# Altium schematic sheet Sch-QWA17_Power.SchDoc(Sch-QWA17_Power)
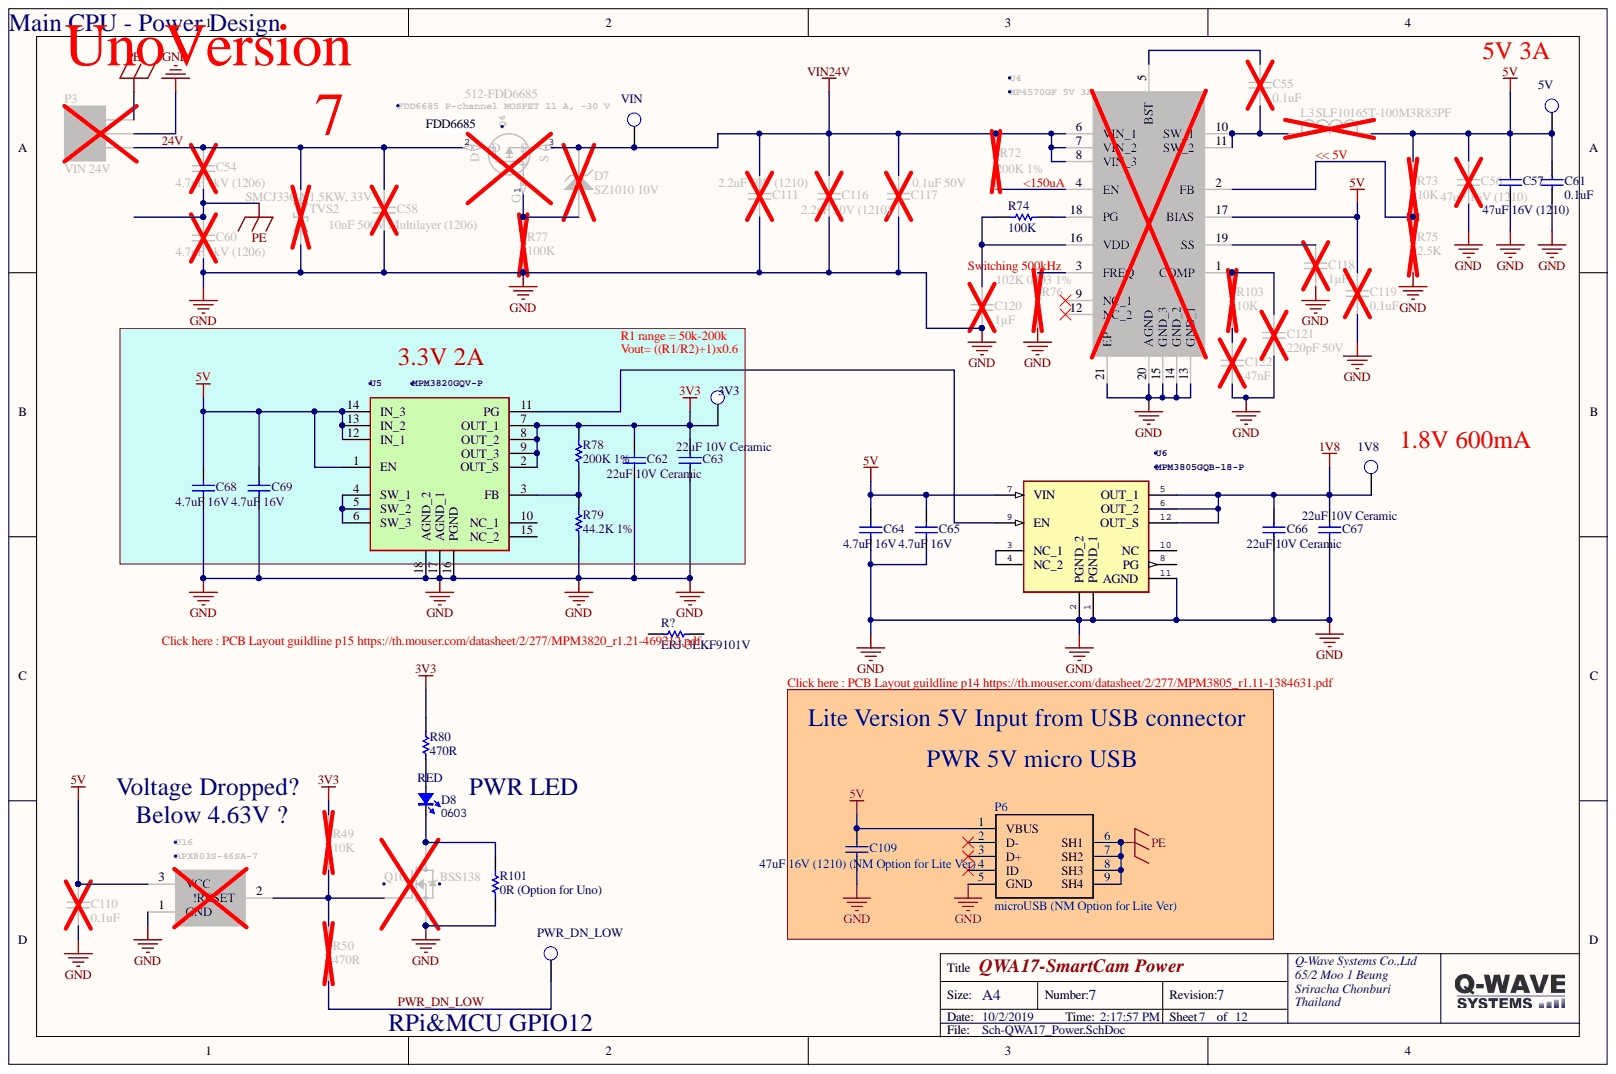
[diagram p0: Sch-QWA17_Power.SchDoc]

## Parts
1V8  1V8  [TEST_POINT]
3V3  3V3  [TEST_POINT]
5V  5V  [TEST_POINT]
C54  4.7nF 1kV (1206)  [C 1206]
C55  0.1uF  [CAP_0603]
C56  47uF 16V (1210)  [C 1210]
C57  47uF 16V (1210)  [C 1210]
C58  10nF 500V Multilayer (1206)  [C 1206]
C60  4.7nF 1kV (1206)  [C 1206]
C61  0.1uF  [CAP_0603]
C62  22uF 10V Ceramic  [CAP_0805]
C63  22uF 10V Ceramic  [CAP_0805]
C64  4.7uF 16V  [CAP_0805]
C65  4.7uF 16V  [CAP_0805]
C66  22uF 10V Ceramic  [CAP_0805]
C67  22uF 10V Ceramic  [CAP_0805]
C68  4.7uF 16V  [CAP_0805]
C69  4.7uF 16V  [CAP_0805]
C109  47uF 16V (1210) (NM Option for Lite Ver)  [C 1210]
C110  0.1uF  [CAP_0603]
C111  2.2uF 50V (1210)  [C 1210]
C116  2.2uF 50V (1210)  [C 1210]
C117  0.1uF 50V  [CAP_0603]
C118  1µF  [CAP_0603]
C119  0.1uF  [CAP_0603]
C120  1µF  [CAP_0603]
C121  220pF 50V  [CAP_0603]
C122  47nF  [CAP_0603]
D7  SZ1010 10V  [DO-214AC_SMA]
D8  RED  Type: 0603  [LED_0603_RED]
L3  SLF10165T-100M3R83PF  [SLF10165T-100M3R83PF]
P3  VIN 24V  [FCI 06-381-3PIN]
P6  microUSB (NM Option for Lite Ver)  [MICRO-USB-CON]
PWR_DN_LOW  PWR_DN_LOW  [TEST_POINT]
Q4  FDD6685 P-channel MOSFET 11 A, -30 V  [FDD6685]
Q10  BSS138  [SOT-23-3]
R49  10K  [RES_0603]
R50  470R  [RES_0603]
R72  200K 1%  [RES_0603]
R73  10K  [RES_0603]
R74  100K  [RES_0603]
R75  2.5K  [RES_0603]
R76  102K 0603 1%  [RES_0603]
R77  100K  [RES_0603]
R78  200K 1%  [RES_0603]
R79  44.2K 1%  [RES_0603]
R80  470R  [RES_0603]
R101  0R (Option for Uno)  [RES_0603]
R103  10K  [RES_0603]
R?  ERJ-3EKF9101V  [RESC1608X55X30NL15T15]
TVS2  SMCJ33CA 1.5KW, 33V  [DO-214AB_SMC]
U4  MP4570GF 5V 3A  [SOP65P640X120-21N]
U5  MPM3820GQV-P  [MPM3820GQVP]
U6  MPM3805GQB-18-P  [MPM3805GQBZ]
U16  APX803S-46SA-7  [SOT95P230X110-3N]
VIN  VIN  [TEST_POINT]

## Nets
1V8: 1V8.1 C66.1 C67.1 U6.5(OUT_1) U6.6(OUT_2) U6.12(OUT_S)
3V3: 3V3.1 C62.1 C63.1 R49.1 R78.2 R80.2 U5.2(OUT_S) U5.7(OUT_1) U5.8(OUT_2) U5.9(OUT_3)
5V: 5V.1 C56.1 C57.1 C61.1 C64.1 C65.1 C68.1 C69.1 C109.1 C110.2 C119.1 L3.1 P6.1(VBUS) R73.1 U4.17(BIAS) U5.1(EN) U5.12(IN_1) U5.13(IN_2) U5.14(IN_3) U6.7(VIN) U16.3
GND: C56.2 C57.2 C58.2 C60.2 C61.2 C62.2 C63.2 C64.2 C65.2 C66.2 C67.2 C68.2 C69.2 C109.2 C110.1 C111.2 C116.2 C117.1 C118.1 C119.2 C120.1 C121.2 C122.2 P3.2 P6.5(GND) Q10.2 R75.2 R76.1 R77.1 R79.1 R101.1 TVS2.1 U4.13(GND_1) U4.14(GND_2) U4.15(GND_3) U4.20(AGND) U4.21(EP) U5.16(PGND) U5.17(AGND_1) U5.18(AGND_2) U6.1(PGND_1) U6.2(PGND_2) U6.11(AGND) U16.1
PE: C54.2 C60.1 P3.1 P6.6(SH1) P6.7(SH2) P6.8(SH3) P6.9(SH4)
VIN24V: C111.1 C116.1 C117.2 D7.2 Q4.3 R72.2 U4.6(VIN_1) U4.7(VIN_2) U4.8(VIN_3) VIN.1
24V: C54.1 C58.1 P3.3 Q4.2 TVS2.2
PWR_DN_LOW: PWR_DN_LOW.1 R50.1
NetC55_1: C55.1 U4.5(BST)
NetC55_2: C55.2 L3.2 U4.10(SW_1) U4.11(SW_2)
NetC118_2: C118.2 U4.19(SS)
NetC120_2: C120.2 R74.2 U4.16(VDD)
NetC121_1: C121.1 R103.1 U4.1(COMP)
NetC122_1: C122.1 R103.2
NetD7_1: D7.1 Q4.1 R77.2
NetD8_1: D8.1 R80.1
NetD8_2: D8.2 Q10.3 R101.2
NetQ10_1: Q10.1 R49.2 R50.2 U16.2
NetR72_1: R72.1 U4.4(EN)
NetR73_2: R73.2 R75.1 U4.2(FB)
NetR74_1: R74.1 U4.18(PG)
NetR76_2: R76.2 U4.3(FREQ)
NetR78_1: R78.1 R79.2 U5.3(FB)
NetU5_4: U5.4(SW_1) U5.5(SW_2) U5.6(SW_3)
NetU5_11: U5.11(PG) U6.9(EN)
NetU6_3: U6.3(NC_1) U6.4(NC_2)

Not connected: P6 2(D-) 3(D+) 4(ID); R? 1 2; U4 9(NC_1) 12(NC_2); U5 10(NC_1) 15(NC_2); U6 8(PG) 10(NC)

## Library data of the parts
1V8 3V3 5V PWR_DN_LOW VIN = TEST_POINT: Test Point; Component Kind: Standard; Library Name: Misc.SCHLIB
C54 C60 = Cap: 4.7nF 1kV (1206) GRM31BR73A472KW01L; ES PN: 0160-0357-1; MFG: KEMET; Component Kind: Standard; ES Link: https://www.es.co.th/detail.asp?Prod=016003571; Library Name: Miscellaneous Devices.IntLib; MFG P/N: GRM31BR73A472KW01L; Simulation: CAP
C55 C61 C110 C117 C119 = Cap Semi: 0.1µF ±5% 50V Ceramic Capacitor X7R 0603; MFG: KEMET; MFG P/N: C0603C104J5RACTU; Component Kind: Standard; Library Name: Miscellaneous Devices.IntLib; Simulation: CAP
C56 C57 C109 = Cap: 47uF 16V Ceramic Capacitor X5R 1210; MFG: KEMET; MFG P/N: C0603C475K8PACTU; Component Kind: Standard; Library Name: Miscellaneous Devices.IntLib; Simulation: CAP
C58 = Cap: Multilayer Ceramic Chip Cap 10nF/500VDC ±10% C1206C103KCRACTU; ES PN: 0306-0175-7; MFG: KEMET; Component Kind: Standard; ES Link: https://www.es.co.th/detail.asp?Prod=030601757; Library Name: Miscellaneous Devices.IntLib; MFG P/N: C1206C103KCRACTU; Simulation: CAP
C62 C63 C66 C67 = Cap: MFG: KEMET; MFG P/N: C0603C475K8PACTU; Component Kind: Standard; Description: 22uF 10V Ceramic; Library Name: Miscellaneous Devices.IntLib; Simulation: CAP
C64 C65 C68 C69 = Cap: 4.7µF ±10% 16V Ceramic Capacitor X5R 0805; MFG: KEMET; MFG P/N: C0603C475K8PACTU; Component Kind: Standard; Library Name: Miscellaneous Devices.IntLib; Simulation: CAP
C111 C116 = Cap: 2.2uF 50V (1210) X7R; Component Kind: Standard; Library Name: Miscellaneous Devices.IntLib; Simulation: CAP
C118 C120 = Cap Semi: 1µF ±5% 50V Ceramic Capacitor X7R 0603; MFG: KEMET; MFG P/N: C0603C104J5RACTU; Component Kind: Standard; Library Name: Miscellaneous Devices.IntLib; Simulation: CAP
C121 = Cap Semi: 220pF 50V Ceramic Capacitor X7R 0603; MFG: KEMET; MFG P/N: C0603C104J5RACTU; Component Kind: Standard; Library Name: Miscellaneous Devices.IntLib; Simulation: CAP
C122 = Cap Semi: 47nF 50V Ceramic Capacitor X7R 0603; MFG: KEMET; MFG P/N: C0603C104J5RACTU; Component Kind: Standard; Library Name: Miscellaneous Devices.IntLib; Simulation: CAP
D7 = D Zener: SZ1010 10V/1W, Iz=25mA; ES PN: 0353-0037-1; Component Kind: Standard; Es Link: https://www.es.co.th/detail.asp?Prod=035300371; LatestRevisionDate: 17-Jul-2002; LatestRevisionNote: Re-released for DXP Platform.; Library Name: Miscellaneous Devices.IntLib; PackageDescription: Diode, Thru-Hole; 2 Leads; 0.7 in Pin Spacing; PackageReference: DIODE-0.7; Published: 8-Jun-2000; Simulation: ZENER; Publisher: Altium Limited
D8 = LED_0603: LED; Value: color?; Component Kind: Standard; Library Name: SCH_Active.SchLib
L3 = SLF10165T-100M3R: Built_By: EMA_UL_Team; Vendor: TDK; Component Kind: Standard; Description: SLF10165T-100M3R83PF; Library Name: SLF10165T-100M3R83PF.IntLib; Manufacturer_Part_Number: SLF10165T-100M3R83PF; Value: Value; Copyright: Copyright (C) 2018 Accelerated Designs. All rights reserved
P3 = Header 3: Header, 3-Pin; Component Kind: Standard; LatestRevisionDate: 17-Jul-2002; LatestRevisionNote: Re-released for DXP Platform.; Library Name: Miscellaneous Connectors.IntLib; Publisher: Altium Limited
P6 = microUSB: Component Kind: Standard; Library Name: USB_PART_ALL.SchLib
Q4 = FDD6685: Fairchild FDD6685 P-channel MOSFET Transistor, 11 A, -30 V, 3-Pin TO-252; Manufacturer_Name: Fairchild Semiconductor; ES P/N: 0123-0628-8; GateName_1: G$1; Component Kind: Standard; DeviceSetName: FDD6685; ES Link: https://www.es.co.th/detail.asp?Prod=012306288; Height: mm; Library Name: FDD6685.IntLib; LibraryName: FDD6685; Manufacturer_Part_Number: FDD6685; Mouser_Part_Number: 512-FDD6685; Mouser_Price-Stock: https://www.mouser.com/Search/Refine.aspx?Keyword=512-FDD6685; RS_Part_Number: 8090916; RS_Price-Stock: http://uk.rs-online.com/web/p/products/8090916; Supplier 1: Mouser; Supplier Part Number 1: 512-FDD6685; SymbolName_1: FDD6685
Q10 = BSS138: N-Channel 50V 220mA (Ta) 360mW (Ta) Surface Mount SOT-23-3; MFG: ON Semiconductor; Availability: Unavailable; Component Kind: Standard; MFG P/N: BSS138; Package: SOT-23 Diodes Inc.; Price: 0.21 USD; Supplier1: ES; Supplier_Part_Number: 0016-0377-1; Supplier_Purchase_URL: https://www.es.co.th/detail.asp?Prod=001603771; Library Name: EspVIEW.IntLib
R49 R72-R75 R77-R79 R101 R103 = Res Semi: Resistor 0603; Component Kind: Standard; Library Name: Miscellaneous Devices.IntLib; Simulation: RES
R50 R80 = Res Semi: 470R Resistor 0603; Component Kind: Standard; Library Name: SCH_Passive.SchLib; Simulation: RES
R76 = Res Semi: RC0603FR-07102KL; Component Kind: Standard; Library Name: Miscellaneous Devices.IntLib; MFG P/N: RC0603FR-07102KL; Simulation: RES
R? = CMP-2000-00684-1: Manufacturer: Panasonic; Component Kind: Standard; Library Name: Altium Content Vault; Part Number: ERJ-3EKF9101V
TVS2 = TVS: TVS 1500W, 33V; ES PN: 0235-0490-1; Component Kind: Standard; ES Link: https://www.es.co.th/detail.asp?Prod=023504901; Library Name: Components.IntLib
U4 = MP4570GF-Z: Manufacturer_Name: Monolithic Power Systems (MPS); GateName_1: G$1; Component Kind: Standard; Description: MP4570GF-Z; DeviceSetName: MP4570GF-Z; Height: 1.2mm; Library Name: MP4570GF-Z.IntLib; LibraryName: MP4570GF-Z; Manufacturer_Part_Number: MP4570GF-Z; Mouser_Part_Number: 946-MP4570GF-Z; Mouser_Price-Stock: https://www.mouser.com/Search/Refine.aspx?Keyword=946-MP4570GF-Z; SymbolName_1: MP4570GF-Z
U5 = MPM3820GQV-P: MPM3820GQV-P VIN 6V, 1.2 MHz,  2A; Manufacturer_Name: Monolithic Power Systems (MPS); GateName_1: G$1; Component Kind: Standard; DeviceSetName: MPM3820GQV-P; Height: mm; Library Name: MPM3820GQV-P.SCHLIB; LibraryName: MPM3820GQV-P; Manufacturer_Part_Number: MPM3820GQV-P; Mouser_Part_Number: 946-MPM3820GQV-P; Supplier 1: Mouser; Supplier Part Number 1: 946-MPM3820GQV-P; SymbolName_1: MPM3820GQV-P
U6 = MPM3805GQB-18-P: MPM3805GQB-18-P  6V 4MHz 600mA; Manufacturer_Name: Monolithic Power Systems (MPS); DeviceSetName: MPM3805GQB-Z; GateName_1: G$1; Component Kind: Standard; Height: 1mm; Library Name: MPM3805GQB-Z.SCHLIB; LibraryName: MPM3805GQB-Z; Manufacturer_Part_Number: MPM3805GQB-Z; Mouser_Part_Number: 946-MPM3805GQBZ; Mouser_Price-Stock: https://www.mouser.com/Search/Refine.aspx?Keyword=946-MPM3805GQBZ; SymbolName_1: MPM3805GQB-Z
U16 = APX803-46SAG-7: Manufacturer_Name: Diodes Inc.; GateName_1: G$1; Component Kind: Standard; Description: APX803S-46SA-7; DeviceSetName: APX803S-46SA-7; Height: 1.1mm; Library Name: APX803-46SAG-7.IntLib; LibraryName: APX803-46SAG-7; Manufacturer_Part_Number: APX803S-46SA-7; Mouser_Part_Number: 621-APX803S-46SA-7; Mouser_Price-Stock: https://www.mouser.com/Search/Refine.aspx?Keyword=621-APX803-46SAG-7; SymbolName_1: APX803S-46SA-7
